# Altium schematic sheet SODIMM_Connector.SchDoc(SODIMM_Connector)
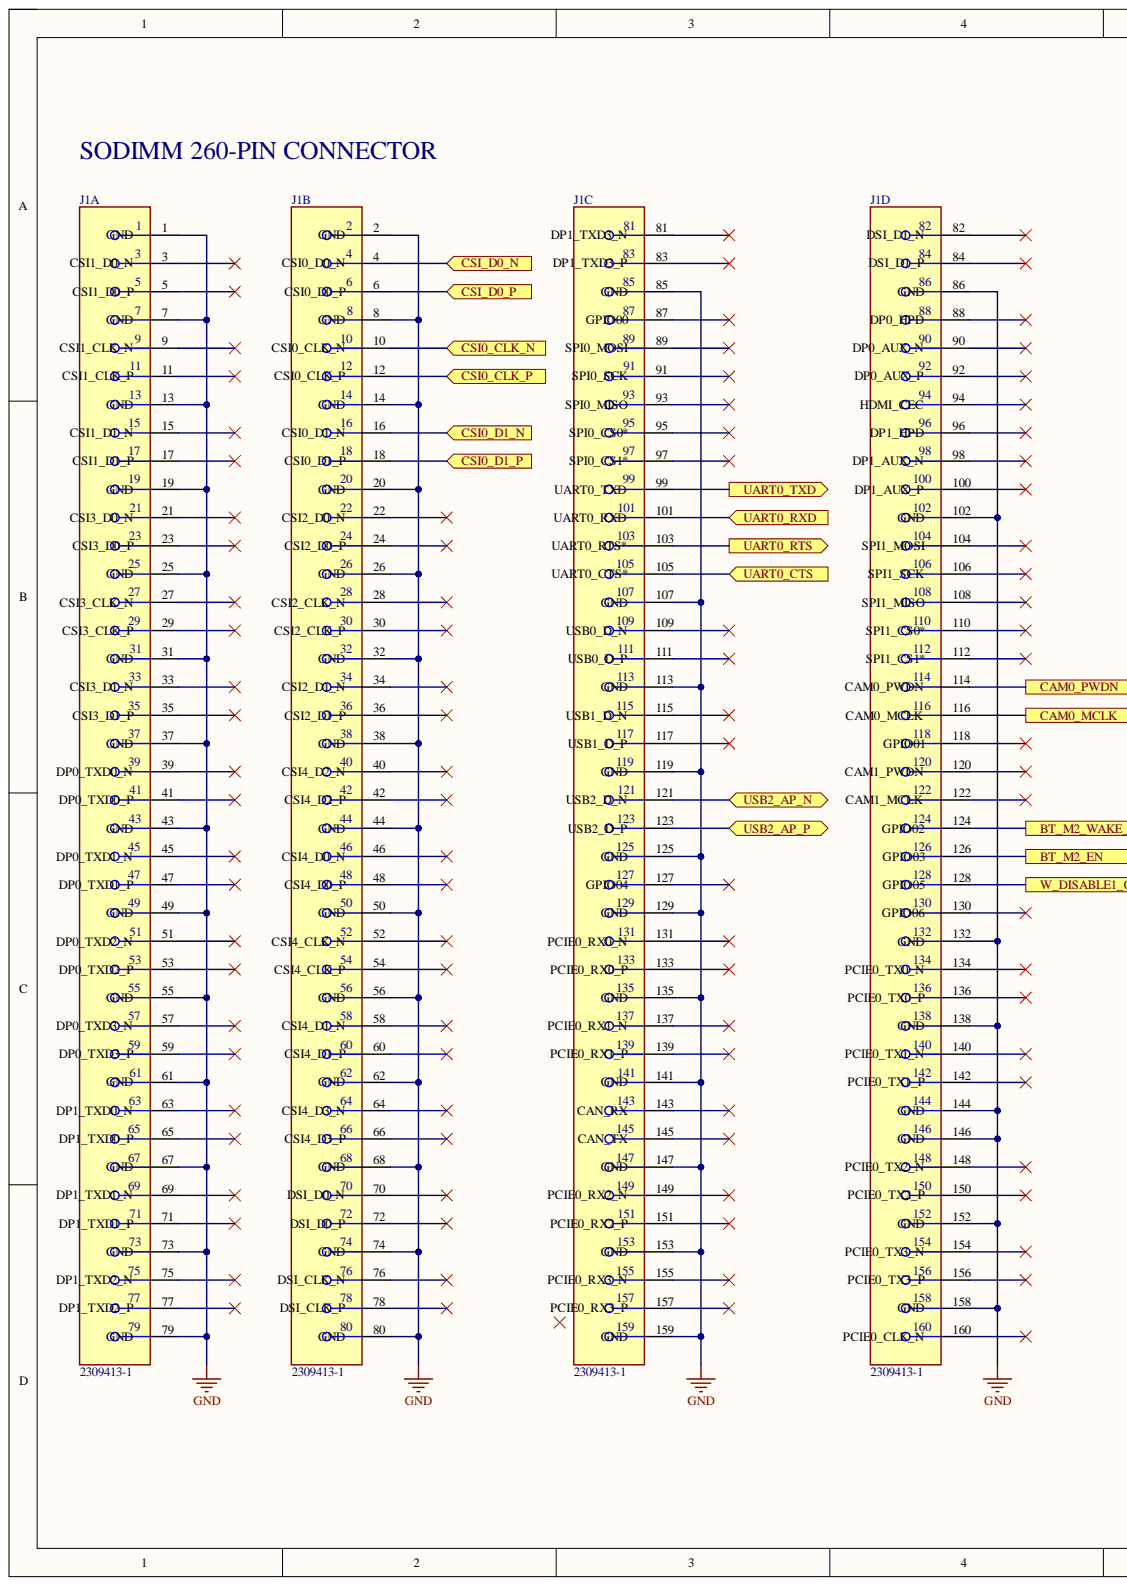
[diagram p0: SODIMM_Connector.SchDoc, left]
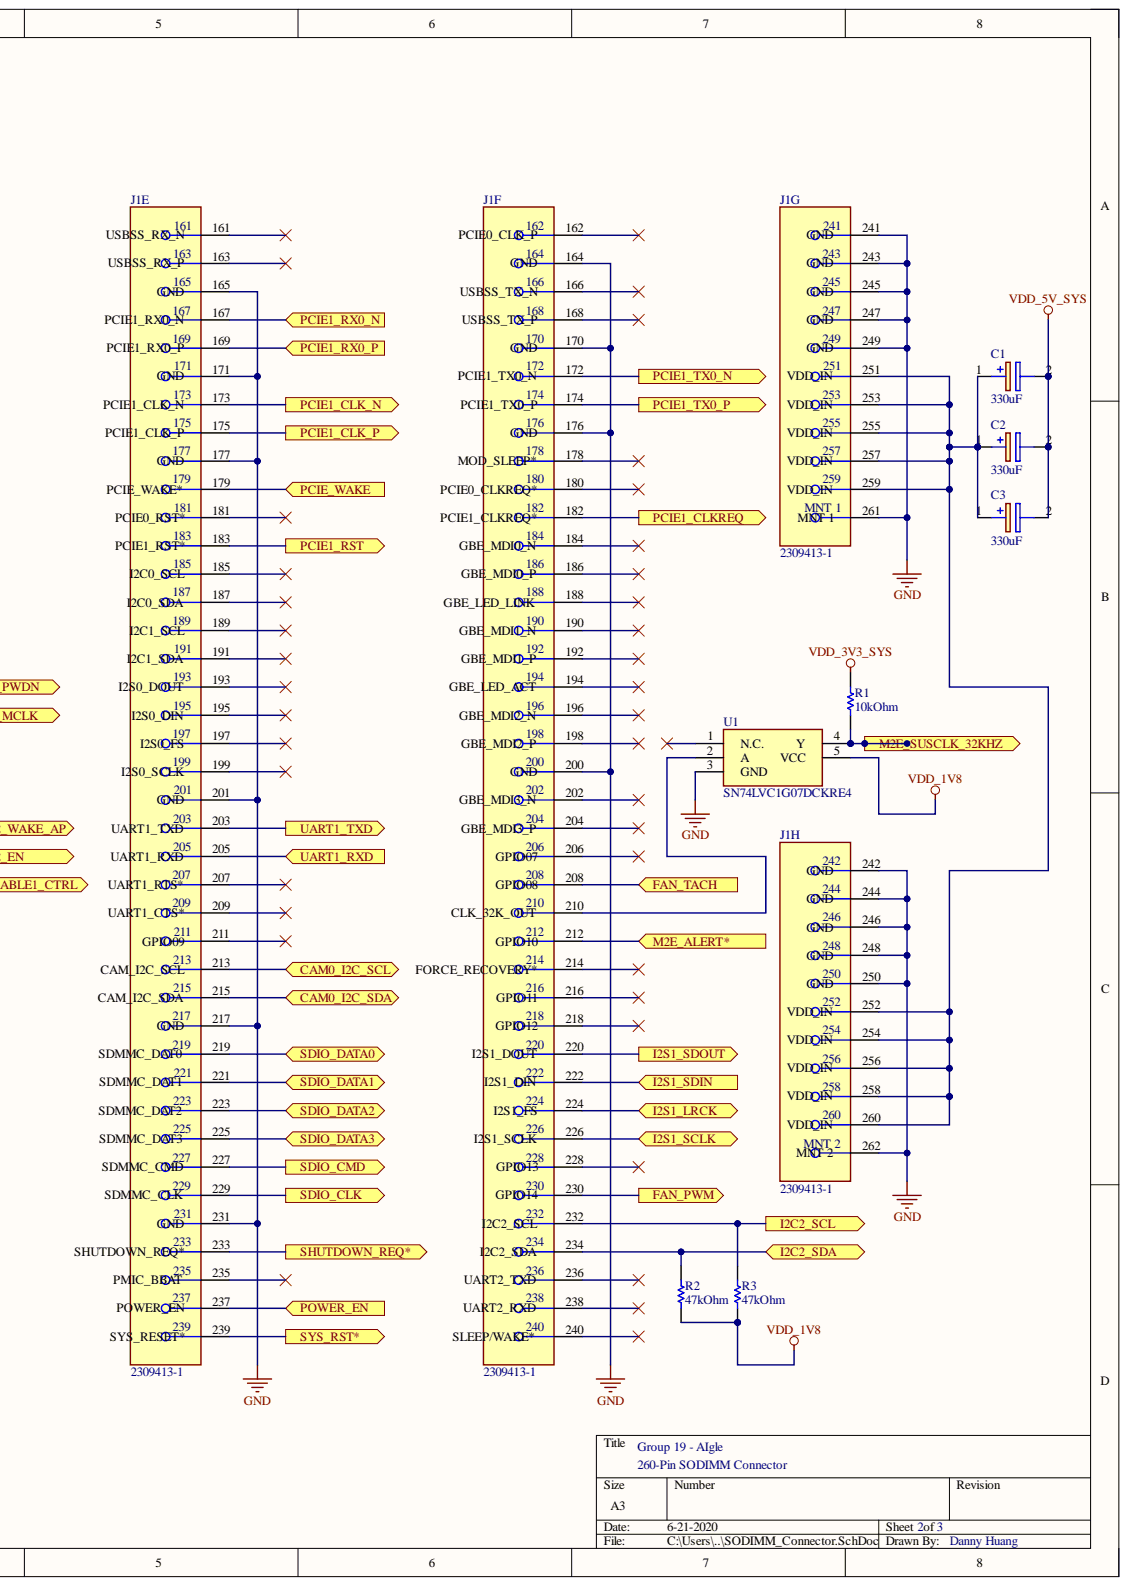
[diagram p1: SODIMM_Connector.SchDoc, right]

## Parts
C1  330uF  [CAPPM3528X210N]
C2  330uF  [CAPPM3528X210N]
C3  330uF  [CAPPM3528X210N]
J1  2309413-1  [FP-2309413-1-MFG]  (parts A,B,C,D,E,F,G,H)
R1  10kOhm  [RESC1005X40X25NL05T05]
R2  47kOhm  [RESC1005X40X25LL05T05]
R3  47kOhm  [RESC1005X40X25LL05T05]
U1  SN74LVC1G07DCKRE4  [SOT65P210X110-5N]

## Ports
BT_M2_EN, BT_M2_WAKE_AP, CAM0_I2C_SCL, CAM0_I2C_SDA, CAM0_MCLK, CAM0_PWDN, CSI0_CLK_N, CSI0_CLK_P, CSI0_D1_N, CSI0_D1_P, CSI_D0_N, CSI_D0_P, FAN_PWM, FAN_TACH, I2C2_SCL, I2C2_SDA, I2S1_LRCK, I2S1_SCLK, I2S1_SDIN, I2S1_SDOUT, M2E_ALERT*, M2E_SUSCLK_32KHZ, PCIE1_CLK_N, PCIE1_CLK_P, PCIE1_CLKREQ, PCIE1_RST, PCIE1_RX0_N, PCIE1_RX0_P, PCIE1_TX0_N, PCIE1_TX0_P, PCIE_WAKE, POWER_EN, SDIO_CLK, SDIO_CMD, SDIO_DATA0, SDIO_DATA1, SDIO_DATA2, SDIO_DATA3, SHUTDOWN_REQ*, SYS_RST*, UART0_CTS, UART0_RTS, UART0_RXD, UART0_TXD, UART1_RXD, UART1_TXD, USB2_AP_N, USB2_AP_P, W_DISABLE1_CTRL

## Nets
GND: J1.1(GND) J1.2(GND) J1.7(GND) J1.8(GND) J1.13(GND) J1.14(GND) J1.19(GND) J1.20(GND) J1.25(GND) J1.26(GND) J1.31(GND) J1.32(GND) J1.37(GND) J1.38(GND) J1.43(GND) J1.44(GND) J1.49(GND) J1.50(GND) J1.55(GND) J1.56(GND) J1.61(GND) J1.62(GND) J1.67(GND) J1.68(GND) J1.73(GND) J1.74(GND) J1.79(GND) J1.80(GND) J1.85(GND) J1.86(GND) J1.102(GND) J1.107(GND) J1.113(GND) J1.119(GND) J1.125(GND) J1.129(GND) J1.132(GND) J1.135(GND) J1.138(GND) J1.141(GND) J1.144(GND) J1.146(GND) J1.147(GND) J1.152(GND) J1.153(GND) J1.158(GND) J1.159(GND) J1.164(GND) J1.165(GND) J1.170(GND) J1.171(GND) J1.176(GND) J1.177(GND) J1.200(GND) J1.201(GND) J1.217(GND) J1.231(GND) J1.241(GND) J1.242(GND) J1.243(GND) J1.244(GND) J1.245(GND) J1.246(GND) J1.247(GND) J1.248(GND) J1.249(GND) J1.250(GND) J1.261(MNT_1) J1.262(MNT_2) U1.3(GND)
VDD_1V8: R2.1 R3.1 U1.5(VCC)
VDD_3V3_SYS: R1.2
VDD_5V_SYS: C1.2 C2.2 C3.2
BT_M2_EN: J1.126(GPIO03) ; port BT_M2_EN
BT_M2_WAKE_AP: J1.124(GPIO02) ; port BT_M2_WAKE_AP
CAM0_I2C_SCL: J1.213 ; port CAM0_I2C_SCL
CAM0_I2C_SDA: J1.215 ; port CAM0_I2C_SDA
CAM0_MCLK: J1.116 ; port CAM0_MCLK
CAM0_PWDN: J1.114 ; port CAM0_PWDN
CSI0_CLK_N: J1.10 ; port CSI0_CLK_N
CSI0_CLK_P: J1.12 ; port CSI0_CLK_P
CSI0_D1_N: J1.16 ; port CSI0_D1_N
CSI0_D1_P: J1.18 ; port CSI0_D1_P
CSI_D0_N: J1.4 ; port CSI_D0_N
CSI_D0_P: J1.6 ; port CSI_D0_P
FAN_PWM: J1.230(GPIO14) ; port FAN_PWM
FAN_TACH: J1.208(GPIO08) ; port FAN_TACH
I2C2_SCL: J1.232(I2C2_SCL) R3.2 ; port I2C2_SCL
I2C2_SDA: J1.234 R2.2 ; port I2C2_SDA
I2S1_LRCK: J1.224(I2S1_FS) ; port I2S1_LRCK
I2S1_SCLK: J1.226 ; port I2S1_SCLK
I2S1_SDIN: J1.222(I2S1_DIN) ; port I2S1_SDIN
I2S1_SDOUT: J1.220 ; port I2S1_SDOUT
M2E_ALERT*: J1.212(GPIO10) ; port M2E_ALERT*
M2E_SUSCLK_32KHZ: R1.1 U1.4(Y) ; port M2E_SUSCLK_32KHZ
PCIE1_CLK_N: J1.173 ; port PCIE1_CLK_N
PCIE1_CLK_P: J1.175 ; port PCIE1_CLK_P
PCIE1_CLKREQ: J1.182 ; port PCIE1_CLKREQ
PCIE1_RST: J1.183 ; port PCIE1_RST
PCIE1_RX0_N: J1.167 ; port PCIE1_RX0_N
PCIE1_RX0_P: J1.169 ; port PCIE1_RX0_P
PCIE1_TX0_N: J1.172 ; port PCIE1_TX0_N
PCIE1_TX0_P: J1.174 ; port PCIE1_TX0_P
PCIE_WAKE: J1.179 ; port PCIE_WAKE
POWER_EN: J1.237 ; port POWER_EN
SDIO_CLK: J1.229 ; port SDIO_CLK
SDIO_CMD: J1.227 ; port SDIO_CMD
SDIO_DATA0: J1.219 ; port SDIO_DATA0
SDIO_DATA1: J1.221 ; port SDIO_DATA1
SDIO_DATA2: J1.223 ; port SDIO_DATA2
SDIO_DATA3: J1.225 ; port SDIO_DATA3
SHUTDOWN_REQ*: J1.233 ; port SHUTDOWN_REQ*
SYS_RST*: J1.239 ; port SYS_RST*
UART0_CTS: J1.105 ; port UART0_CTS
UART0_RTS: J1.103 ; port UART0_RTS
UART0_RXD: J1.101 ; port UART0_RXD
UART0_TXD: J1.99 ; port UART0_TXD
UART1_RXD: J1.205 ; port UART1_RXD
UART1_TXD: J1.203 ; port UART1_TXD
USB2_AP_N: J1.121 ; port USB2_AP_N
USB2_AP_P: J1.123 ; port USB2_AP_P
W_DISABLE1_CTRL: J1.128(GPIO05) ; port W_DISABLE1_CTRL
NetC1_1: C1.1 C2.1 C3.1 J1.251(VDD_IN) J1.252(VDD_IN) J1.253(VDD_IN) J1.254(VDD_IN) J1.255(VDD_IN) J1.256(VDD_IN) J1.257(VDD_IN) J1.258(VDD_IN) J1.259(VDD_IN) J1.260(VDD_IN)
NetJ1_210: J1.210 U1.2(A)

Not connected: J1 3 5 9 11 15 17 21 22 23 24 27 28 29 30 33 34 35 36 39 40 41 42 45 46 47 48 51 52 53 54 57 58 59 60 63 64 65 66 69 70 71 72(DSI_D0_P) 75 76 77 78 81 82(DSI_D1_N) 83 84(DSI_D1_P) 87(GPIO00) 88(DP0_HPD) 89 90 91(SPI0_SCK) 92 93 94 95(SPI0_CS0*) 96(DP1_HPD) 97(SPI0_CS1*) 98 100 104 106(SPI1_SCK) 108 109 110 111 112 115 117 118(GPIO01) 120 122 127(GPIO04) 130(GPIO06) 131 133 134 136 137 139 140 142 143(CAN_RX) 145(CAN_TX) 148 149 150 151 154 155 156 157 160 161 162 163 166 168 178 180 181 184 185(I2C0_SCL) 186 187(I2C0_SDA) 188 189(I2C1_SCL) 190 191(I2C1_SDA) 192 193 194 195(I2S0_DIN) 196 197(I2S0_FS) 198 199 202 204 206(GPIO07) 207 209 211(GPIO09) 214 216(GPIO11) 218(GPIO12) 228(GPIO13) 235 236 238 240; U1 1(N.C.)

## Library data of the parts
C1-C3 = T520B337M006ATE040: Capacitor Polarised; Manufacturer_Name: Kemet; Arrow Part Number: T520B337M006ATE040; Arrow Price/Stock: https://www.arrow.com/en/products/t520b337m006ate040/kemet-corporation; Component Kind: Standard; Datasheet Link: http://componentsearchengine.com/Datasheets/2/T520B337M006ATE040.pdf; Height: 2.1mm; Manufacturer_Part_Number: T520B337M006ATE040; Mouser Part Number: 80-T520B337M06ATE040; Mouser Price/Stock: https://www.mouser.com/Search/Refine.aspx?Keyword=80-T520B337M06ATE040
J1 = CMP-03356-000001-1: DDR4 SODIMM 260P 9.2H STD; Manufacturer: TE Connectivity; Conn Orientation: Horizontal; Current Rating: 0.5A; Is Female: yes; Memory Type: SDRAM,DDR; Number Of Contacts: 260; Pitch: 0.5mm; Voltage Rating: 25V; Automotive: No; Category: Conn; Component Kind: Standard; ComponentLink2Description: Datasheet; ComponentLink2URL: https://www.te.com/commerce/DocumentDelivery/DDEController?Action=showdoc&DocId=Specification+Or+Standard%7F108-115122%7FA1%7Fpdf%7FEnglish%7FENG_SS_108-115122_A1.pdf%7F2309413-1; Contact Material: Gold,Nickel; Device Class L1: Connectors; Device Class L2: Memory Connectors; Device Class L3: unset; Digikey Description: DDR4 SODIMM 260P 9.2H STD; Footprint Url: https://www.te.com/commerce/DocumentDelivery/DDEController?Action=showdoc&DocId=Customer+Drawing%7F2309413%7F4%7Fpdf%7FEnglish%7FENG_CD_2309413_4.pdf%7F2309413-1; Height: 18.8mm; Lead Free: Yes; Library Name: Altium Content Vault; Manufacturer Part Number: 2309413-1; Mouser Description: Conn DDR4 DIMM Socket SKT 260 POS 0.5mm Solder RA SMD T/R; Octopart: https://octopart.com/2309413-1-te+connectivity-84799194; Package: 0; RoHS: TRUE; Temperature: -55°C to +85°C; Temperature Range High: +85°C; Temperature Range Low: -55°C
R1 = CMP-2002-08136-1: Manufacturer: Vishay Dale; Part Number: CRCW040210K0JNED; Component Kind: Standard; Library Name: Altium Content Vault
R2 R3 = CMP-2002-00026-1: Manufacturer: Vishay Dale; Part Number: CRCW040247K0JNED; Component Kind: Standard; Library Name: Altium Content Vault
U1 = SN74LVC1G07DCKRE4: Integrated Circuit; Manufacturer_Name: Texas Instruments; Arrow Part Number: SN74LVC1G07DCKRE4; Arrow Price/Stock: https://www.arrow.com/en/products/sn74lvc1g07dckre4/texas-instruments; Component Kind: Standard; Datasheet Link: http://www.ti.com/lit/ds/symlink/sn74lvc1g07.pdf; Height: 1.1mm; Manufacturer_Part_Number: SN74LVC1G07DCKRE4; Mouser Part Number: 595-SN74LVC1G07DCKE4; Mouser Price/Stock: https://www.mouser.co.uk/ProductDetail/Texas-Instruments/SN74LVC1G07DCKRE4?qs=pajgIaoyDUhxFR39KLTAuA%3D%3D
